# Altium schematic sheet Page2_Conector Ethernet.SchDoc
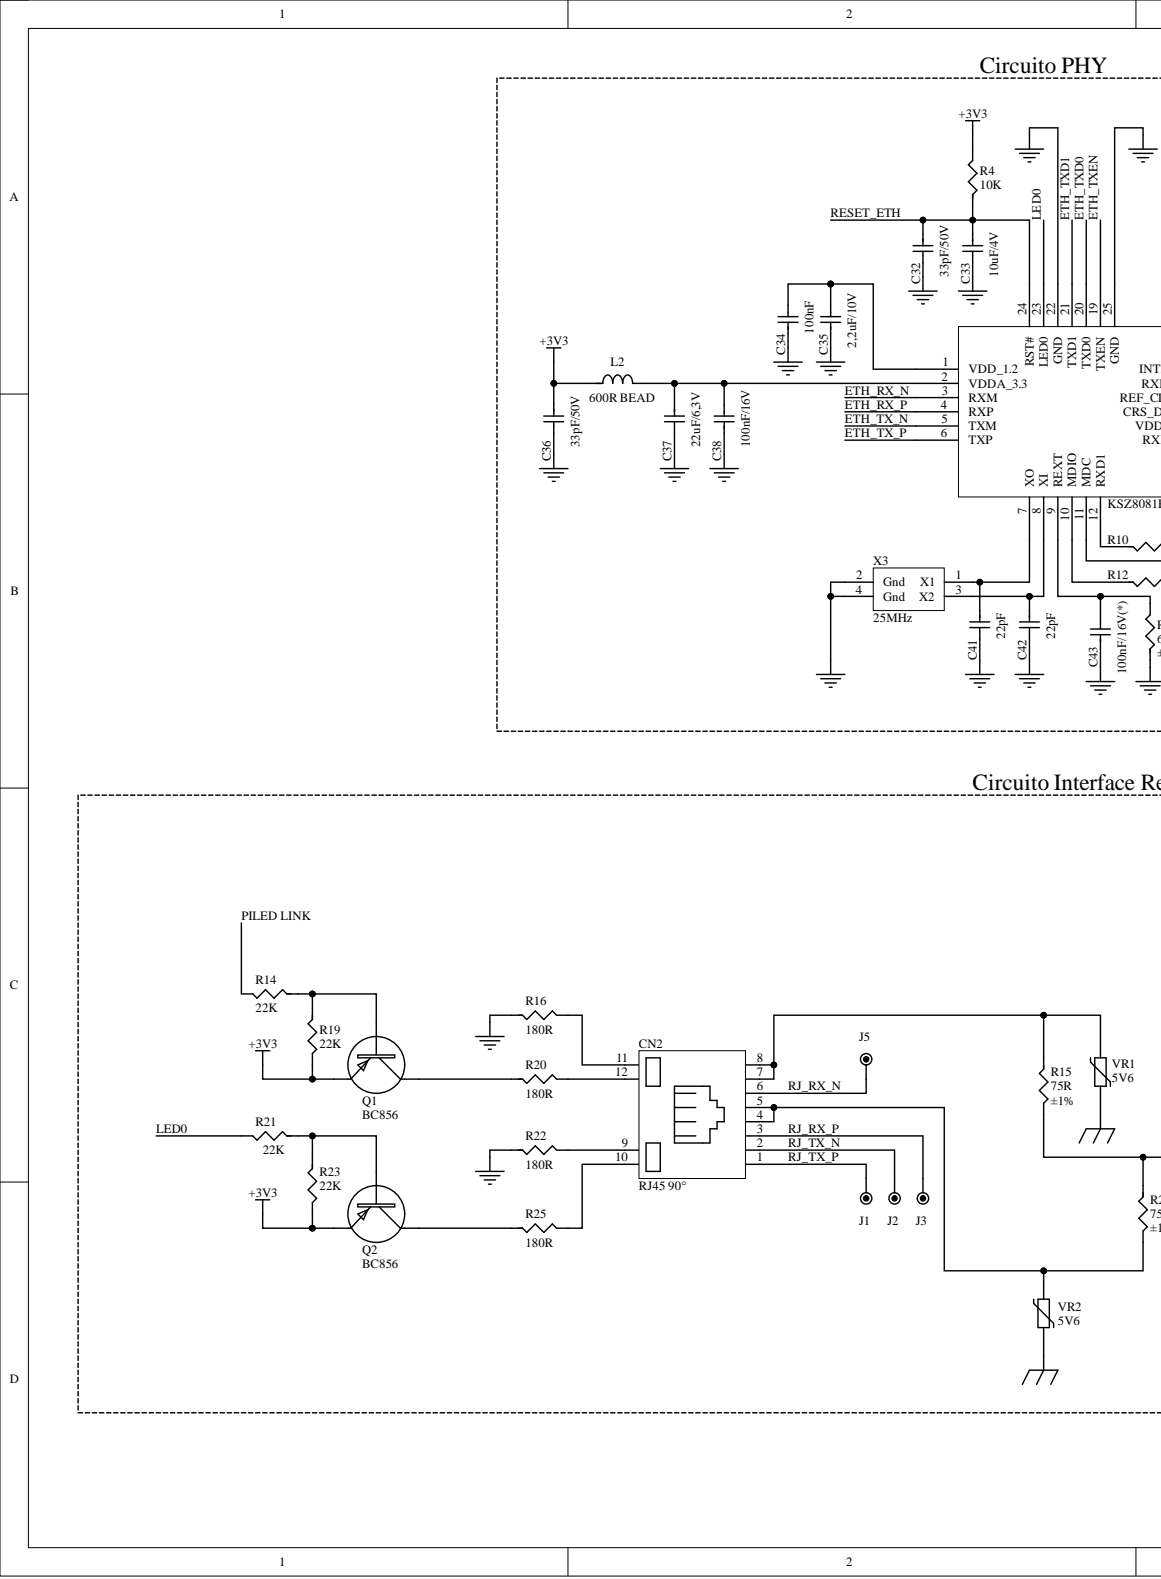
[diagram p0: Page2_Conector Ethernet.SchDoc, left]
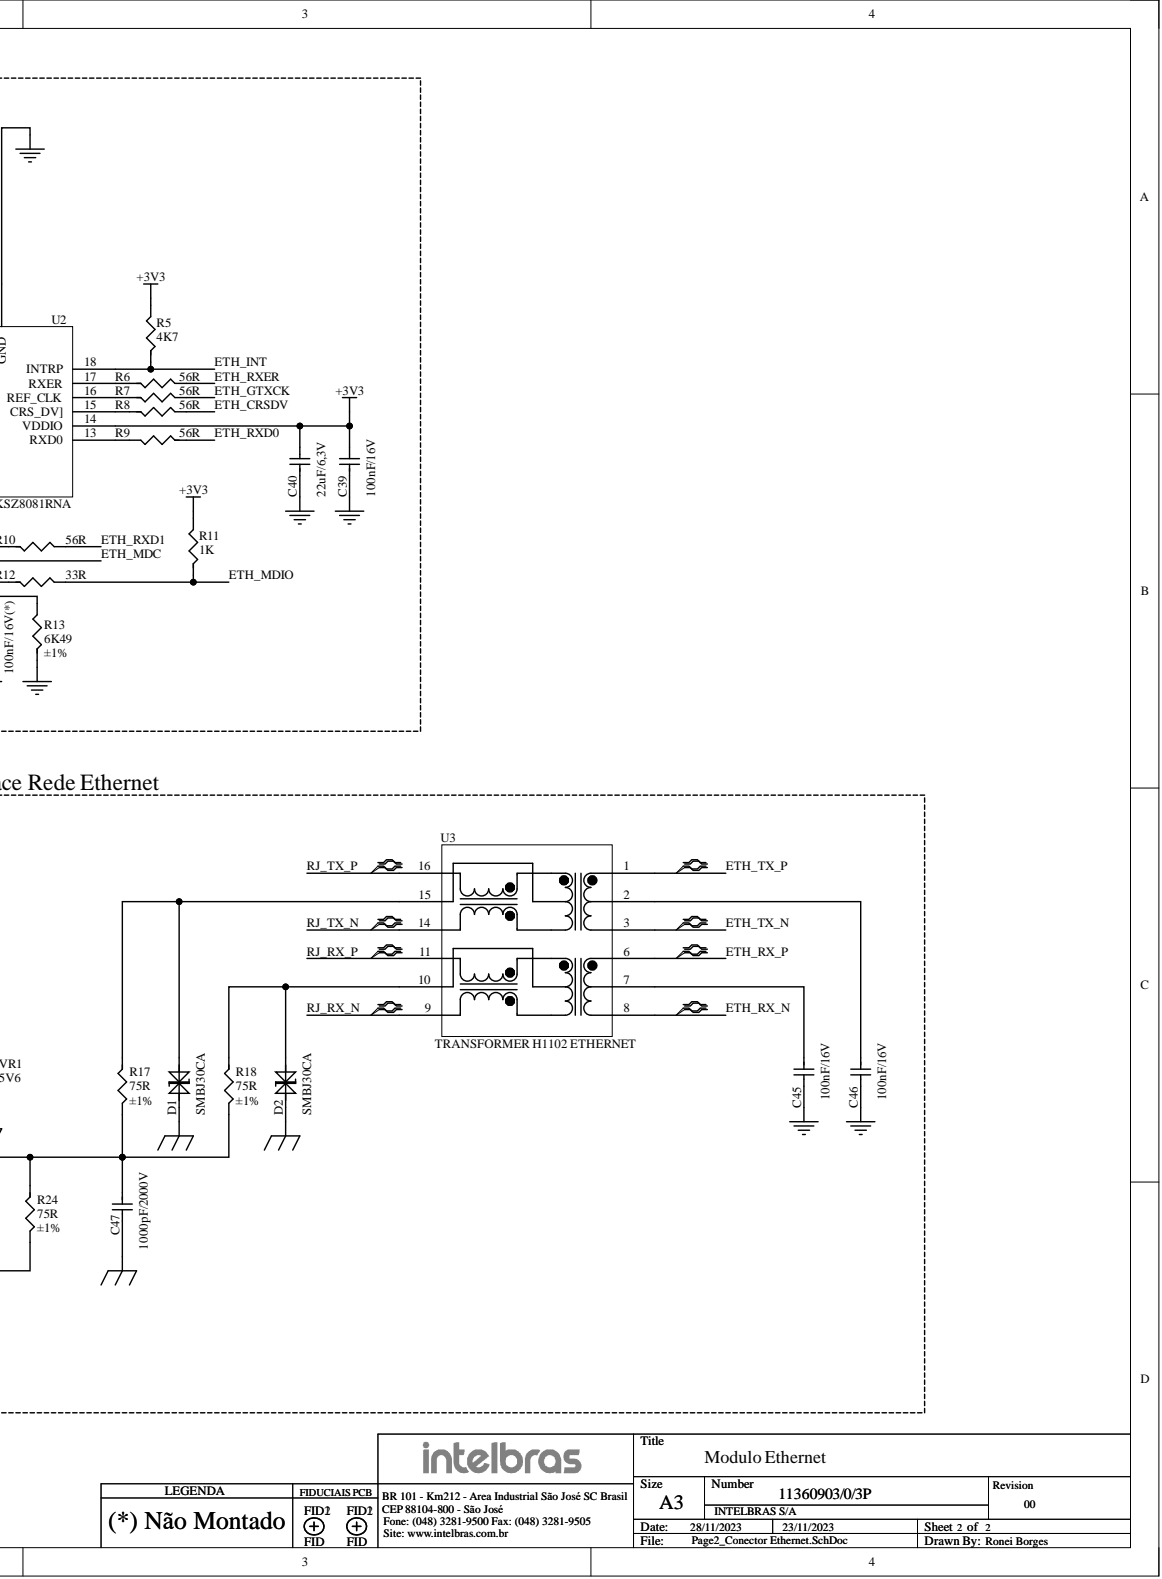
[diagram p1: Page2_Conector Ethernet.SchDoc, right]

## Parts
C32  33pF/50V  [0402C]
C33  10uF/4V  [0603C]
C34  100nF  [0402C]
C35  2,2uF/10V  [0402C]
C36  33pF/50V  [0402C]
C37  22uF/6,3V  [0805C]
C38  100nF/16V  [0402C]
C39  100nF/16V  [0402C]
C40  22uF/6,3V  [0805C]
C41  22pF  [0402C]
C42  22pF  [0402C]
C43  100nF/16V(*)  [0402C]
C45  100nF/16V  [0402C]
C46  100nF/16V  [0402C]
C47  1000pF/2000V  [1206C]
CN2  RJ45 90°  [CON_PTH_RJ45_C16L16.8A12.95-8_ML]
D1  SMBJ30CA  [SMB]
D2  SMBJ30CA  [SMB]
J1  testpoint  [TP_60]  (value not drawn)
J2  testpoint  [TP_60]  (value not drawn)
J3  testpoint  [TP_60]  (value not drawn)
J5  testpoint  [TP_60]  (value not drawn)
L2  600R BEAD  [0603L]
Q1  BC856  [SOT23-BCE]
Q2  BC856  [SOT23-BCE]
R4  10K  [0402]
R5  4K7  [0402]
R6  56R  [0402]
R7  56R  [0402]
R8  56R  [0402]
R9  56R  [0402]
R10  56R  [0402]
R11  1K  [0402]
R12  33R  [0402]
R13  6K49  %: ±1%  [0603]
R14  22K  [0603]
R15  75R  %: ±1%  [0603]
R16  180R  [0603]
R17  75R  %: ±1%  [0603]
R18  75R  %: ±1%  [0603]
R19  22K  [0603]
R20  180R  [0603]
R21  22K  [0603]
R22  180R  [0603]
R23  22K  [0603]
R24  75R  %: ±1%  [0603]
R25  180R  [0603]
U2  KSZ8081RNA  [QFN_P0.5C4L4A0.85-24THM]
U3  TRANSFORMER H1102 ETHERNET  [H1102_SMD_P1.27C12.9L8.8A6.15-16]
VR1  5V6  [0805]
VR2  5V6  [0805]
X3  25MHz  [OSC_SMD_C3.2L2.5A0.75 - b]

## Nets
+3V3: C36.1 C39.2 C40.2 L2.1 Q1.E Q2.E R4.2 R5.2 R11.2 R19.2 R23.2 U2.14(VDDIO)
GND: C32.2 C33.2 C34.1 C35.1 C36.2 C37.2 C38.1 C39.1 C40.1 C41.2 C42.2 C43.1 C45.2 C46.2 R13.1 R16.1 R22.1 U2.22(GND) U2.25(GND) X3.2(Gnd) X3.4(Gnd)
GND_EARTH: C47.2 D1.A D2.A VR1.1 VR2.2
ETH_CRSDV: R8.2
ETH_GTXCK: R7.2
ETH_INT: R5.1 U2.18(INTRP)
ETH_MDC: U2.11(MDC)
ETH_MDIO: R11.1 R12.2
ETH_RX_N: U2.3(RXM) U3.8
ETH_RX_P: U2.4(RXP) U3.6
ETH_RXD0: R9.1
ETH_RXD1: R10.2
ETH_RXER: R6.2
ETH_TX_N: U2.5(TXM) U3.3
ETH_TX_P: U2.6(TXP) U3.1
ETH_TXD0: U2.20(TXD0)
ETH_TXD1: U2.21(TXD1)
ETH_TXEN: U2.19(TXEN)
LED0: R21.2 U2.23(LED0)
PILED LINK: R14.2
RESET_ETH: C32.1 C33.1 R4.1 U2.24(RST#)
RJ_RX_N: CN2.6 J5.1 U3.9
RJ_RX_P: CN2.3 J3.1 U3.11
RJ_TX_N: CN2.2 J2.1 U3.14
RJ_TX_P: CN2.1 J1.1 U3.16
NetC34_2: C34.2 C35.2 U2.1(VDD_1.2)
NetC37_1: C37.1 C38.2 L2.2 U2.2(VDDA_3.3)
NetC41_1: C41.1 U2.7(XO) X3.1(X1)
NetC42_1: C42.1 U2.8(XI) X3.3(X2)
NetC43_2: C43.2 R13.2 U2.9(REXT)
NetC45_1: C45.1 U3.7
NetC46_1: C46.1 U3.2
NetC47_1: C47.1 R15.2 R17.2 R18.2 R24.1
NetCN2_4: CN2.4 CN2.5 R24.2 VR2.1
NetCN2_7: CN2.7 CN2.8 R15.1 VR1.2
NetCN2_9: CN2.9 R22.2
NetCN2_10: CN2.10 R25.2
NetCN2_11: CN2.11 R16.2
NetCN2_12: CN2.12 R20.2
NetD1_K: D1.K R17.1 U3.15
NetD2_K: D2.K R18.1 U3.10
NetQ1_B: Q1.B R14.1 R19.1
NetQ1_C: Q1.C R20.1
NetQ2_B: Q2.B R21.1 R23.1
NetQ2_C: Q2.C R25.1
NetR6_1: R6.1 U2.17(RXER)
NetR7_1: R7.1 U2.16(REF_CLK)
NetR8_1: R8.1 U2.15(CRS_DV])
NetR9_2: R9.2 U2.13(RXD0)
NetR10_1: R10.1 U2.12(RXD1)
NetR12_1: R12.1 U2.10(MDIO)

## Library data of the parts
C32 C36 = 1313731: Capacitor MLC SMD 0402 NP0 50 V ±5% 33 pF; Component Kind: Standard; Library Name: Capacitor.SchLib
C33 = 1313874: Capacitor MLC SMD 0603 X5R 4 V ±20% 10 uF; %: ±20%; Component Kind: Standard; Library Name: Capacitor.SchLib
C34 C38 C39 C43 C45 C46 = 1313697: Capacitor MLC SMD 0402 X7R 16 V ±10% 100 nF; Component Kind: Standard; Library Name: Capacitor.SchLib
C35 = 1310219: Capacitor SMD MLC 0402 X5R 10V 2,2uF ±10%; Component Kind: Standard; Library Name: Capacitor.SchLib
C37 C40 = 1313891: CP MC SMD 0805 X5R 6.3V +/-20% 22uF; %: ±20%; Component Kind: Standard; Library Name: Capacitor.SchLib
C41 C42 = 1310134: Capacitor SMD MLC 0402 NP0 50V ±5% 22pF; Component Kind: Standard; Library Name: Capacitor.SchLib
C47 = 1313902: CP MC SMD 1206 1000pF 2000V +/-10%; Component Kind: Standard; Library Name: Capacitor.SchLib
CN2 = 1570020: Tomada PTH 1x1 RJ45 FM 8P8C 90° 150V 1,5A c/Led; Component Kind: Standard; Library Name: Conectores.SchLib
D1 D2 = 1090036: Diodo SMD Supressor SMB 48,4V SMBJ30CA; Component Kind: Standard; Library Name: Diodos.SchLib
J1-J3 J5 = TestPoint_Jiga: Component Kind: Standard; Library Name: Diversos.SchLib
L2 = 1430418: Indutor Bead SMD 0603 ±25% 600 R 100 MHz 0,2 A; Component Kind: Standard; Library Name: Indutor.SchLib
Q1 Q2 = 1060333: Transistor SMD SOT-23 N BC856B; Component Kind: Standard; Library Name: Transistor.SchLib
R4 = 1015398: Resistor SMD 0402 1/16 W ±1% 10 kR; P: 1/16W; %: ±1%; Component Kind: Standard; Library Name: Resistor.SchLib
R5 = 1015370: Resistor SMD 0402 1/16 W ±5% 4,7 k; Component Kind: Standard; Library Name: Resistor.SchLib
R6-R10 = 1015378: Resistor SMD 0402 1/16 W ±5% 56 R; Component Kind: Standard; Library Name: Resistor.SchLib
R11 = 1015153: Resistor SMD 0402 1/16 W ±5% 1 kR; P: 1/16W; %: ±5%; Component Kind: Standard; Library Name: Resistor.SchLib
R12 = 1015377: Resistor SMD 0402 1/16 W ±5% 33R; PartNumber1: RC0402JR-0733R; DescricaoFamilia: Resistores; Fabricante1: YAGEO CORPORATION; Fabricante2: FENGHUA; Familia: 10117001; QtdFabricante: 4; QtdFornecedor: 1; Saldo: 126105; Situacao: Ativo; UnidadeMedida1: mi; Valor1: 0.47; Valor2: 0; Component Kind: Standard; Descricao: Resistor SMD 0402 1/16 W ±5% 33 R; PartNumber2: RC02W33RJT; Library Name: ems.dblib
R13 = 1015200: Resistor SMD 0603 1/10 W ±1% 6,49 kR; Component Kind: Standard; Library Name: Resistor.SchLib
R14 R19 R21 R23 = 1014064: Resistor SMD 0603 1/10 W ±5% 22 kR; %: ±5%; Component Kind: Standard; Library Name: Resistor.SchLib
R15 R17 R18 R24 = 1015281: Resistor SMD 0603 1/10 W ±1% 75 R; Component Kind: Standard; Library Name: Resistor.SchLib
R16 R20 R22 R25 = 1014625: Resistor SMD 0603 1/10 W ±5% 180 R; Component Kind: Standard; Library Name: Resistor.SchLib
U2 = 1020316: CI SMD Transceptor Ethernet QFN-24 KSZ8081RNACA; Component Kind: Standard; Library Name: CIs.SchLib
U3 = 1110463: Transformador Dados SMD 100 MHz 0,8 VA Ethernet; Component Kind: Standard; Library Name: Trafo.SchLib
VR1 VR2 = 1070259: Varistor MOV SMD 0805 ±10% 5,6 V; Component Kind: Standard; Library Name: Proteção.SchLib
X3 = 1380026: Cristal SMD RSD 3225 22pF ±50ppm 40R 25MHz; Component Kind: Standard; Library Name: Osciladores.SchLib
